# Altium schematic sheet Schemeatic_1_0.SchDoc(Schemeatic_1_0)
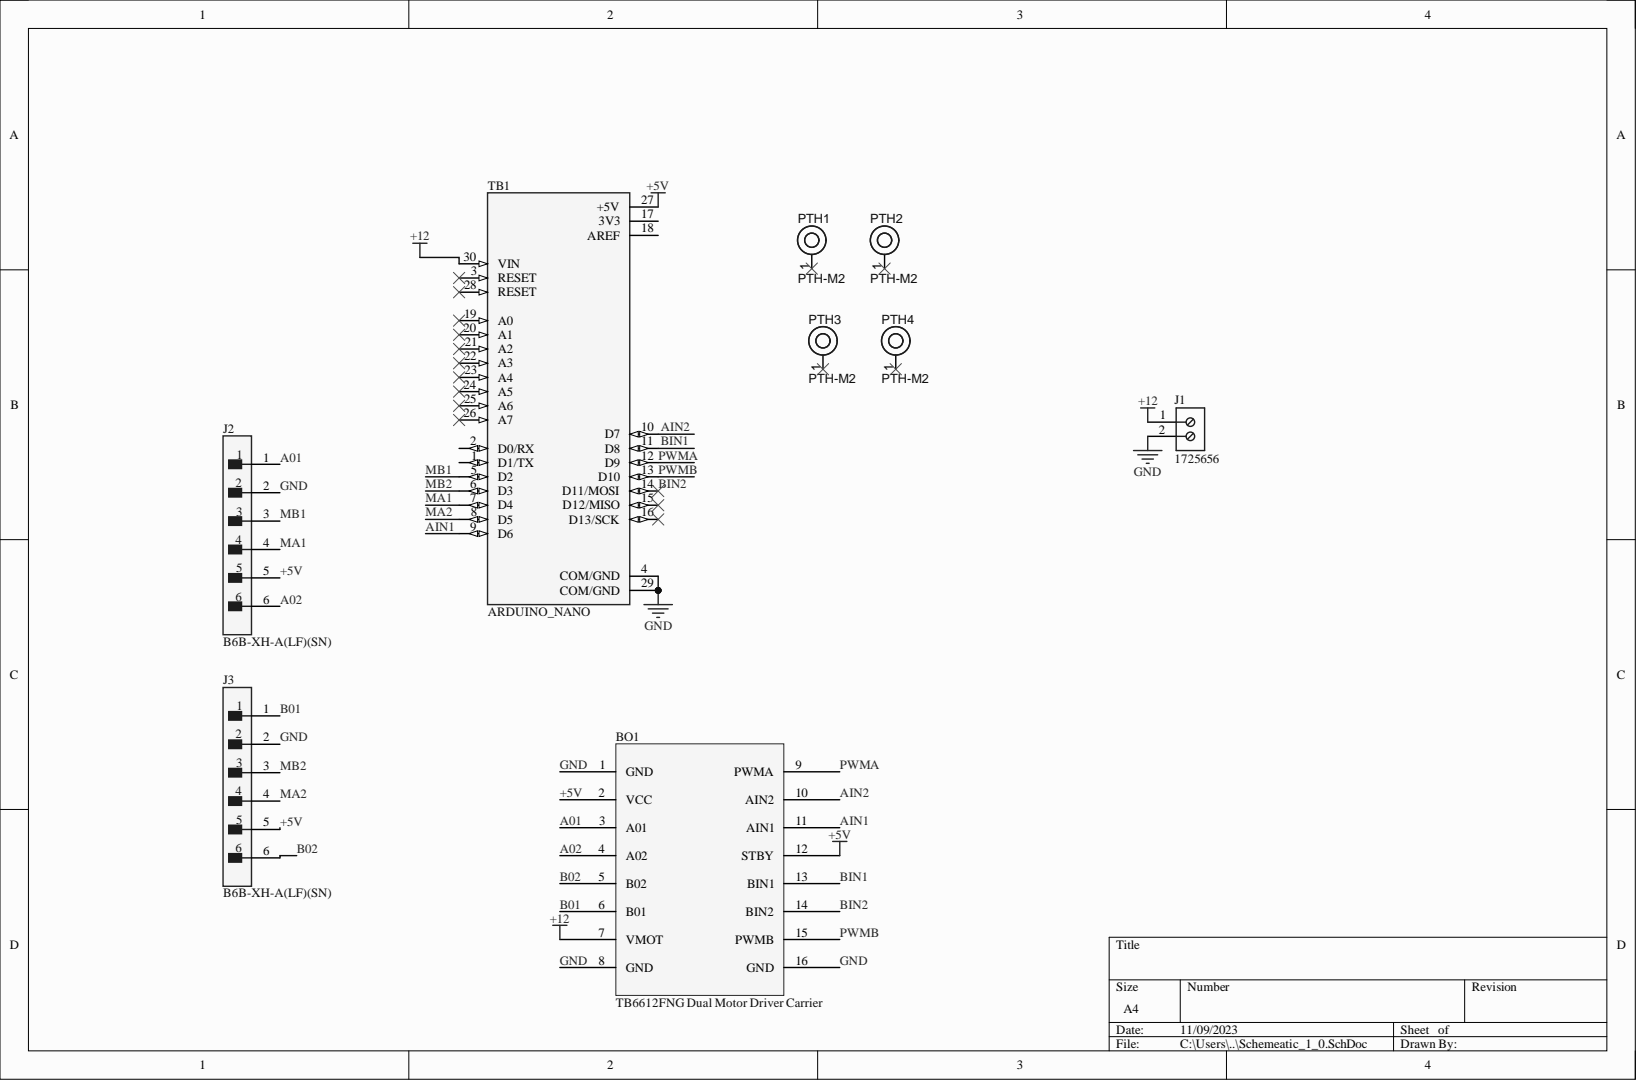
[diagram p0: Schemeatic_1_0.SchDoc]

## Parts
BO1  TB6612FNG Dual Motor Driver Carrier  [DIP16 - duplicate1]
J1  1725656  [PHOE-1725656-2]
J2  B6B-XH-A(LF)(SN)  [FP-B6B-XH-A_LF_SN-MFG]
J3  B6B-XH-A(LF)(SN)  [FP-B6B-XH-A_LF_SN-MFG]
PTH1  PTH-M2  [PTH-M2]
PTH2  PTH-M2  [PTH-M2]
PTH3  PTH-M2  [PTH-M2]
PTH4  PTH-M2  [PTH-M2]
TB1  ARDUINO_NANO  [SHIELD_ARDUINO_NANO]

## Nets
+12: BO1.7(VMOT) J1.1 TB1.30(VIN)
+5V: BO1.2(VCC) BO1.12(STBY) J2.5 J3.5 TB1.27(+5V)
A01: BO1.3(A01) J2.1
A02: BO1.4(A02) J2.6
AIN1: BO1.11(AIN1) TB1.9(D6)
AIN2: BO1.10(AIN2) TB1.10(D7)
B01: BO1.6(B01) J3.1
B02: BO1.5(B02) J3.6
BIN1: BO1.13(BIN1) TB1.11(D8)
BIN2: BO1.14(BIN2) TB1.14(D11/MOSI)
GND: BO1.1(GND) BO1.8(GND) BO1.16(GND) J1.2 J2.2 J3.2 TB1.4(COM/GND) TB1.29(COM/GND)
MA1: J2.4 TB1.7(D4)
MA2: J3.4 TB1.8(D5)
MB1: J2.3 TB1.5(D2)
MB2: J3.3 TB1.6(D3)
PWMA: BO1.9(PWMA) TB1.12(D9)
PWMB: BO1.15(PWMB) TB1.13(D10)

Not connected: PTH1 1; PTH2 1; PTH3 1; PTH4 1; TB1 1(D1/TX) 2(D0/RX) 3(RESET) 15(D12/MISO) 16(D13/SCK) 17(3V3) 18(AREF) 19(A0) 20(A1) 21(A2) 22(A3) 23(A4) 24(A5) 25(A6) 26(A7) 28(RESET)

## Library data of the parts
BO1 = TB6612FNG_Breakout: Component Kind: Standard; Library Name: motor_16_driver.IntLib
J1 = CMP-2000-05299-1: Male Header, Pitch 2.54 mm, 1 x 2 Position, Height 9 mm, Tail Length 3.5 mm; Manufacturer: Phoenix Contact; Content: 250pc(s); Industrial packaging: Yes; Number of contacts: 2; Pitch: 2.54mm; Colour: Green; Component Kind: Standard; Datasheet URL: http://datasheet.octopart.com/1725656-Phoenix-Contact-datasheet-5302866.pdf; Datasheet Version: Apr-08; Library Name: Altium Content Vault; Manufacturer part No.: 1725656; Manufacturer URL: http://www.phoenixcontact.com/; Type: MPT0,5/2-2,54
J2 J3 = CMP-17439-000076-1: CONN HEADER VERT 6POS 2.5MM; Component Kind: Standard; Library Name: Altium Content Vault
PTH1-PTH4 = CMP-00028-00016-2: M2 Clearance (2.2 mm) Plated Through Hole, 6mm Diameter Pad; Component Kind: Standard; Design Item ID: CMP-00003-1; Pins: 1; Source: Components - 02.08.23 - 11.22.SchLib; Created By: Robert Howie; Library Name: AERO3001 Spacecraft Project
TB1 = ARDUINO_NANO: Small, complete, and breadboard-friendly board based on the ATmega328 (Arduino Nano 3.x); Availability: Not in stock; Check_prices: https://www.snapeda.com/parts/Arduino%20Nano/Arduino/view-part/?ref=eda; Component Kind: Standard; Library Name: ARDUINO_NANO.IntLib; MF: Arduino; MP: Arduino Nano; Package: Non-Standard Arduino; Price: None; SnapEDA_Link: https://www.snapeda.com/parts/Arduino%20Nano/Arduino/view-part/?ref=snap
